# Altium schematic sheet schemaPower.SchDoc
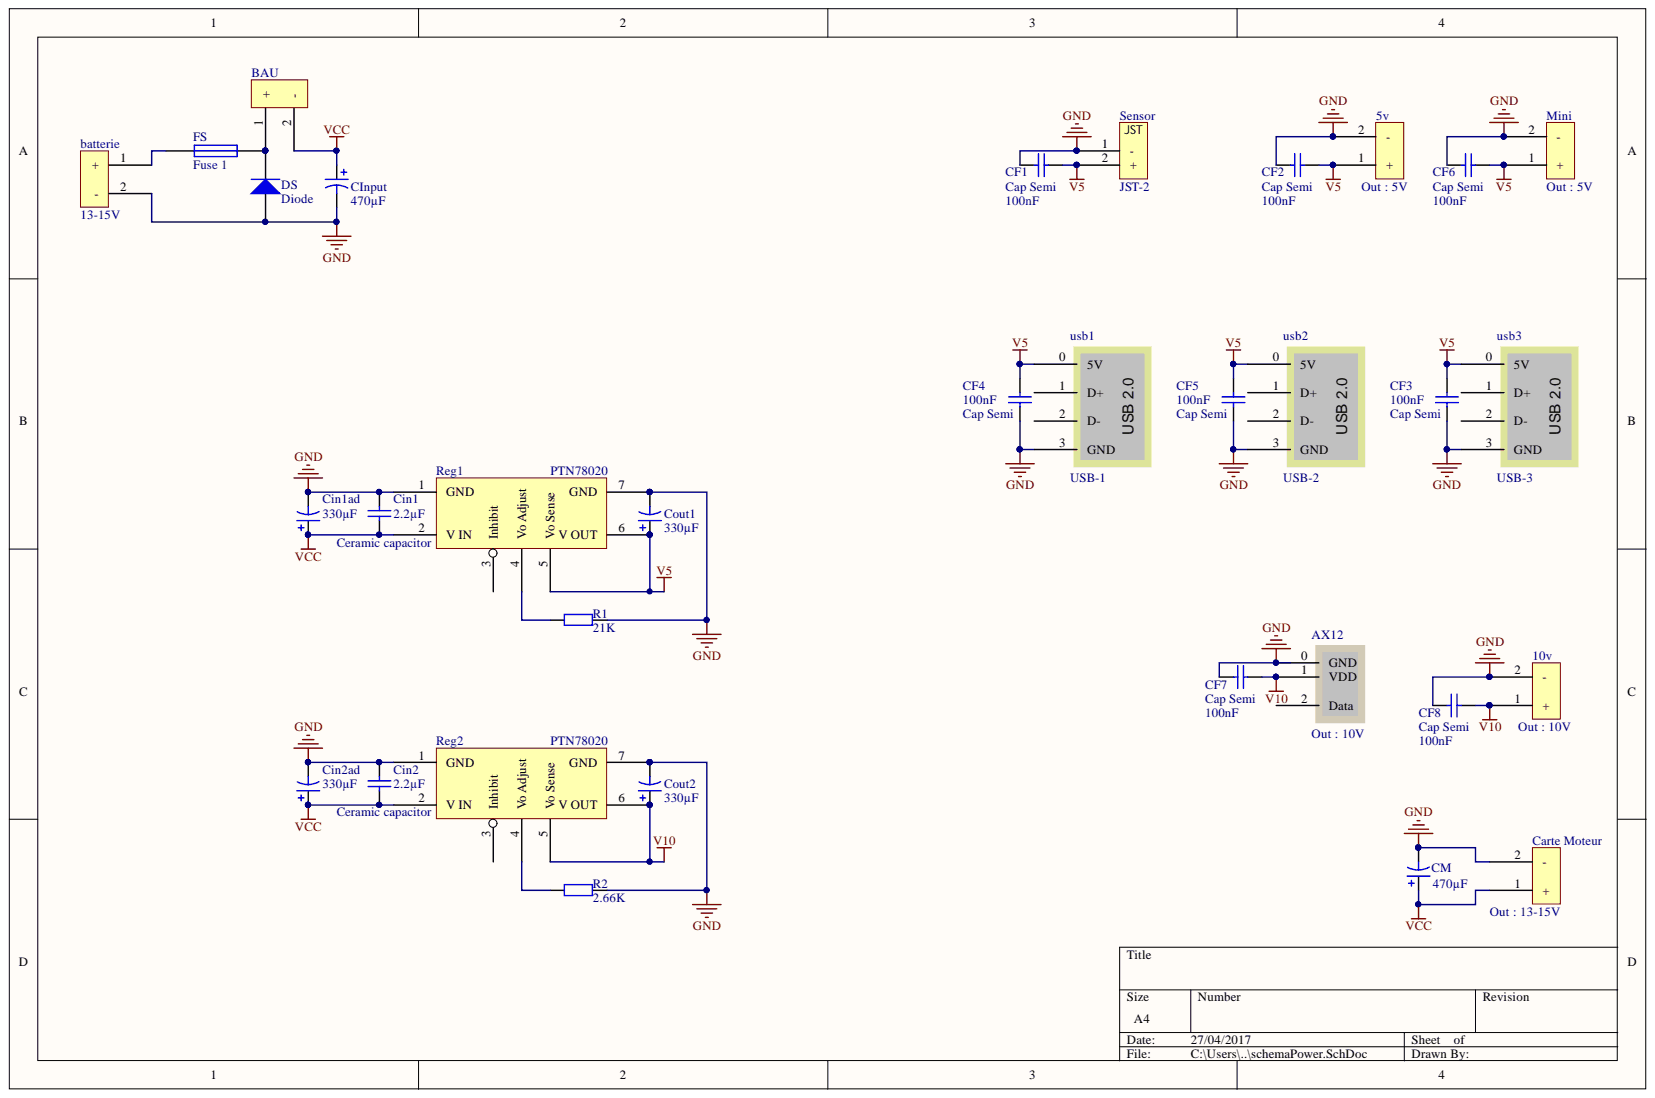
[diagram p0: schemaPower.SchDoc]

## Parts
5v  Out : 5V  [KRE 02]
10v  Out : 10V  [KRE 02]
AX12  Out : 10V  [22-03-5035]
batterie  13-15V  [KRE 02]
BAU  BBDU  [KRE 02]  (value not drawn)
Carte_Moteur  Out : 13-15V  [KRE 02]
CF1  Cap Semi  value 100nF  [C1206]
CF2  Cap Semi  value 100nF  [C1206]
CF3  Cap Semi  value 100nF  [C1206]
CF4  Cap Semi  value 100nF  [C1206]
CF5  Cap Semi  value 100nF  [C1206]
CF6  Cap Semi  value 100nF  [C1206]
CF7  Cap Semi  value 100nF  [C1206]
CF8  Cap Semi  value 100nF  [C1206]
Cin1  Ceramic capacitor  value 2.2µF  [AVX1206]
Cin1ad  value 330µF  [ZKG1C330]
Cin2  Ceramic capacitor  value 2.2µF  [AVX1206]
Cin2ad  value 330µF  [ZKG1C330]
CInput  value 470µF  [ZKG1C330]
CM  value 470µF  [ZKG1C330]
Cout1  value 330µF  [ZKG1C330]
Cout2  value 330µF  [ZKG1C330]
DS  Diode  [TO-220-AB]
FS  Fuse 1  [2 BeClipUp]
Mini  Out : 5V  [KRE 02]
R1  value 21K  [AXIAL-0.4]
R2  value 2.66K  [AXIAL-0.4]
Reg1  PTN78020  [EUK_R-PDSS-T7]
Reg2  PTN78020  [EUK_R-PDSS-T7]
Sensor  JST-2  [B02B-PASK-1]
usb1  USB-1  [USB-A]
usb2  USB-2  [USB-A]
usb3  USB-3  [USB-A]

## Nets
GND: 5v.2 10v.2 AX12.0 batterie.2 Carte_Moteur.2 CF1.1 CF2.1 CF3.1 CF4.1 CF5.1 CF6.2 CF7.1 CF8.2 Cin1.2 Cin1ad.2 Cin2.2 Cin2ad.2 CInput.2 CM.2 Cout1.2 Cout2.2 DS.1 Mini.2 R1.2 R2.2 Reg1.1(GND) Reg1.7(GND) Reg2.1(GND) Reg2.7(GND) Sensor.1 usb1.3(GND) usb2.3(GND) usb3.3(GND)
V5: 5v.1 CF1.2 CF2.2 CF3.2 CF4.2 CF5.2 CF6.1 Cout1.1 Mini.1 Reg1.5(Vo_Sense) Reg1.6(V_OUT) Sensor.2 usb1.0(5V) usb2.0(5V) usb3.0(5V)
V10: 10v.1 AX12.1 CF7.2 CF8.1 Cout2.1 Reg2.5(Vo_Sense) Reg2.6(V_OUT)
VCC: BAU.2 Carte_Moteur.1 Cin1.1 Cin1ad.1 Cin2.1 Cin2ad.1 CInput.1 CM.1 Reg1.2(V_IN) Reg2.2(V_IN)
Netbatterie_1: batterie.1 FS.1
NetBAU_1: BAU.1 DS.2 FS.2
NetR1_1: R1.1 Reg1.4(Vo_Adjust)
NetR2_1: R2.1 Reg2.4(Vo_Adjust)

Not connected: AX12 2; Reg1 3(Inhibit); Reg2 3(Inhibit); usb1 1(D+) 2(D-); usb2 1(D+) 2(D-); usb3 1(D+) 2(D-)

## Library data of the parts
5v 10v batterie BAU Carte_Moteur Mini = KRE 02 ?: Component Kind: Standard; Library Name: EvoSchematic.SchLib
AX12 = 22-03-5035 ?: Component Kind: Standard; Library Name: EvoSchematic.SchLib
CF1-CF8 = Cap Semi: Capacitor (Semiconductor SIM Model); Component Kind: Standard; LatestRevisionDate: 29-May-2009; LatestRevisionNote: IPC-7351 Footprint Added.; Library Name: Miscellaneous Devices.IntLib; PackageDescription: Chip Capacitor; PackageReference: C1206; Published: 8-Jun-2000; Publisher: Altium Limited; Signal Integrity: Cap Semi; Simulation: CAP
Cin1 Cin2 = Cap: Capacitor; Component Kind: Standard; LatestRevisionDate: 17-Jul-2002; LatestRevisionNote: Re-released for DXP Platform.; Library Name: Miscellaneous Devices.IntLib; PackageDescription: Radial Cap, Thru-Hole; 2 Leads; 0.3 in Pin Spacing; PackageReference: RAD-0.3; Published: 8-Jun-2000; Publisher: Altium Limited; Signal Integrity: Cap; Simulation: CAP
Cin1ad Cin2ad CInput CM Cout1 Cout2 = Cap Pol1: Polarized Capacitor (Radial); Component Kind: Standard; LatestRevisionDate: 17-Jul-2002; LatestRevisionNote: Re-released for DXP Platform.; Library Name: Miscellaneous Devices.IntLib; PackageDescription: Polarized Capacitor (Radial); 2 Leads; PackageReference: RB7.6-15; Published: 8-Jun-2000; Publisher: Altium Limited; Signal Integrity: Cap Pol; Simulation: CAP
DS = Diode: Default Diode; Code_JEDEC: DO-214-AB; Component Kind: Standard; LatestRevisionDate: 17-Jul-2002; LatestRevisionNote: Re-released for DXP Platform.; Library Name: Miscellaneous Devices.IntLib; PackageDescription: DO-214-AB/SMC; 2 C-Bend Leads; Body 7.9 x 5.9 mm, inc. leads (LxW); PackageReference: SMC; PackageVersion: Sep-1996; Published: 8-Jun-2000; Publisher: Altium Limited; Signal Integrity: Diode; Simulation: DIODE
FS = Fuse 1: Fuse; Component Kind: Standard; LatestRevisionDate: 17-Jul-2002; LatestRevisionNote: Re-released for DXP Platform.; Library Name: Miscellaneous Devices.IntLib; PackageDescription: Thru-Hole; 2 Leads; PackageReference: PIN-W2/E2.8; Published: 8-Jun-2000; Publisher: Altium Limited; Simulation: FUSE
R1 R2 = Res2: Resistor; Component Kind: Standard; LatestRevisionDate: 17-Jul-2002; LatestRevisionNote: Re-released for DXP Platform.; Library Name: Miscellaneous Devices.IntLib; PackageDescription: Axial Device, Thru-Hole; 2 Leads; 0.4 in Pin Spacing; PackageReference: AXIAL-0.4; Published: 8-Jun-2000; Publisher: Altium Limited; Signal Integrity: Res; Simulation: RESISTOR
Reg1 Reg2 = PTN78020 ?: Component Kind: Standard; Library Name: EvoSchematic.SchLib
usb1-usb3 = USB-?: Component Kind: Standard; Library Name: EvoSchematic.SchLib
Sensor = JST-2: Component Kind: Standard; Library Name: EvoSchematic.SchLib
